# Altium schematic sheet RAK3172.SchDoc(RAK3172)
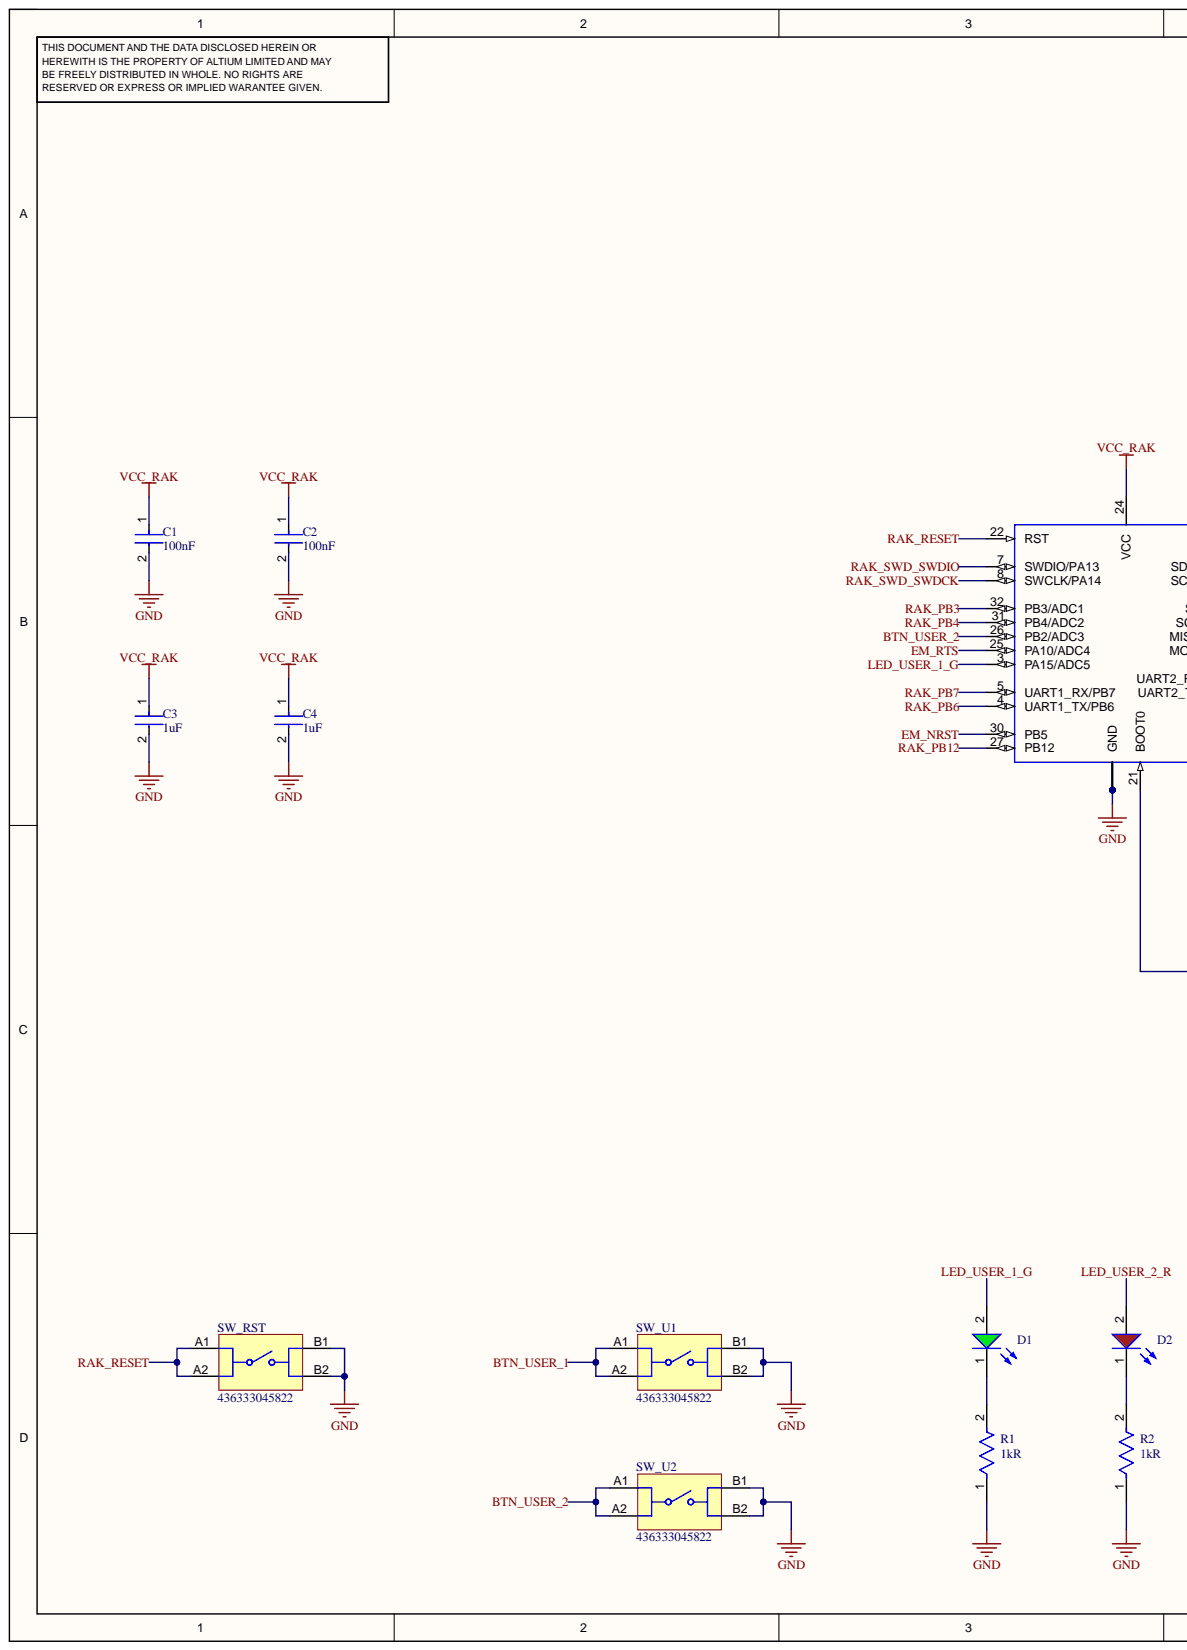
[diagram p0: RAK3172.SchDoc, left]
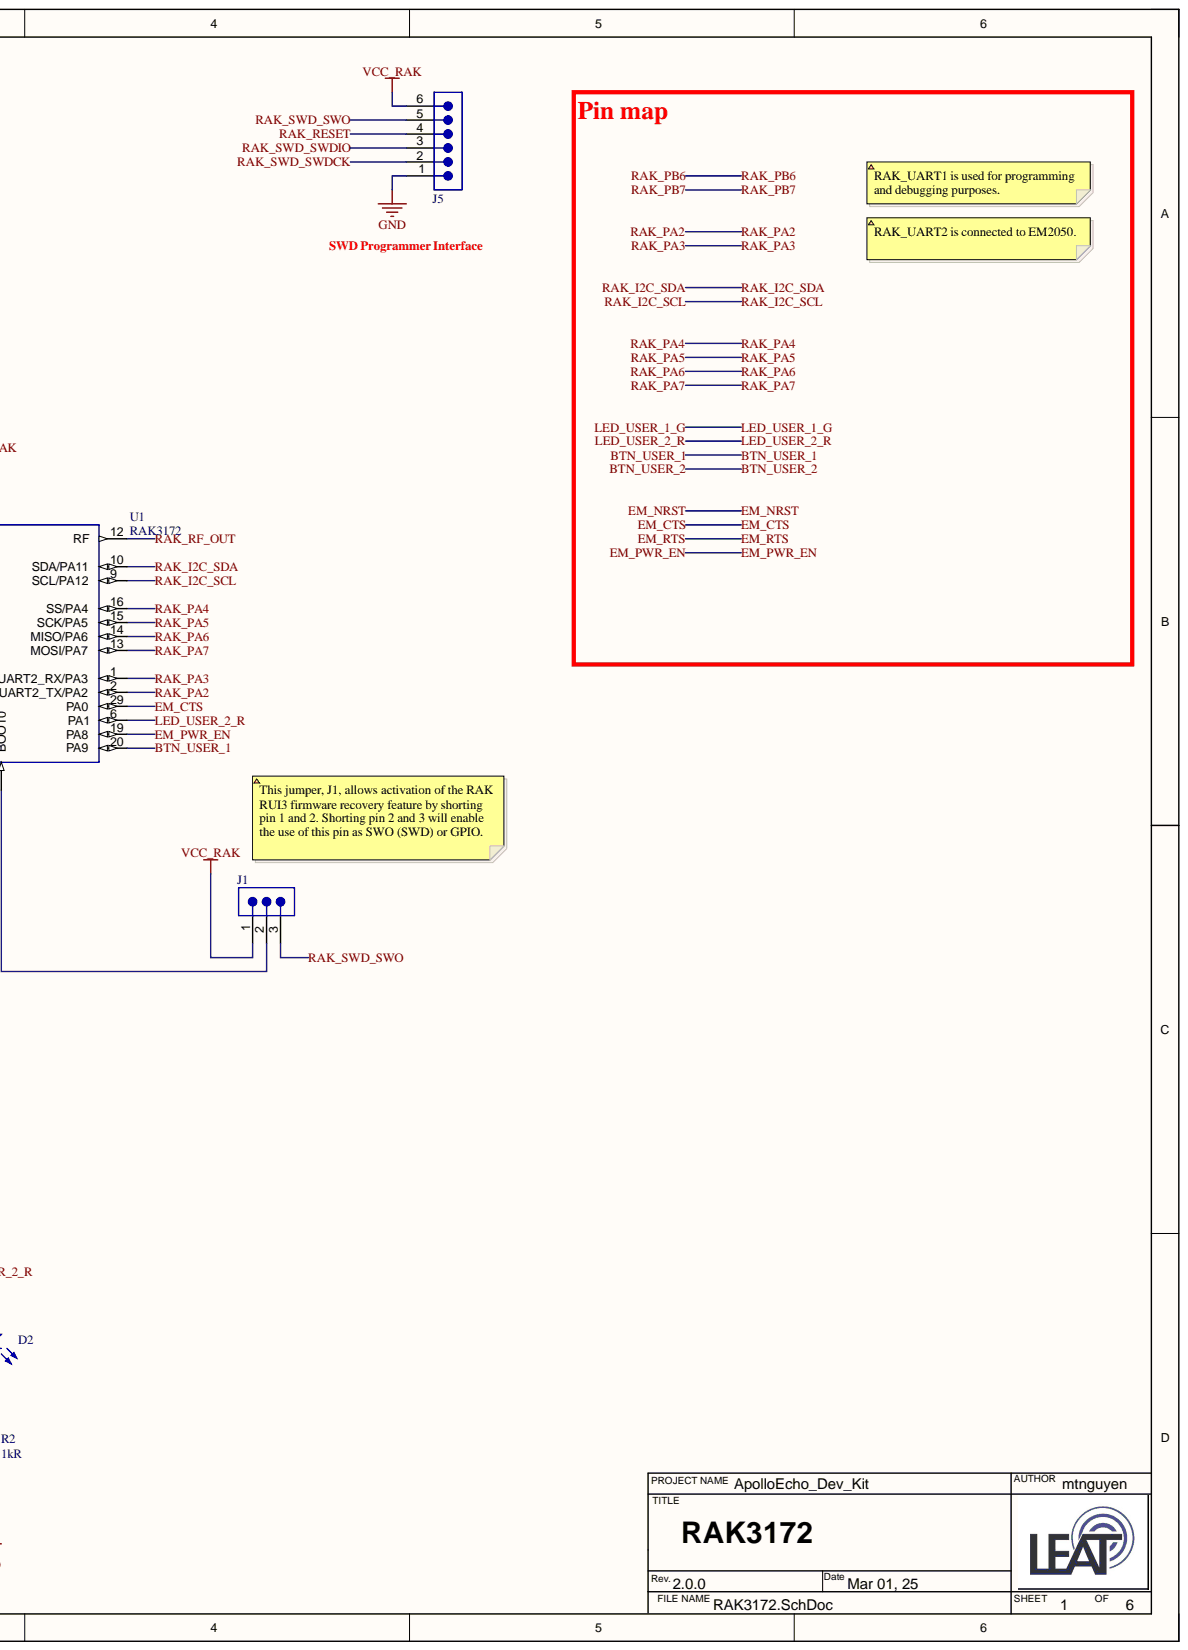
[diagram p1: RAK3172.SchDoc, right]

## Parts
C1  Capacitance: 100nF  [FP-0402-L_1_0_1-W_0_5_0_1-IPC_C]  (hidden comment: 0402ZD104KAT2A)
C2  Capacitance: 100nF  [FP-0402-L_1_0_1-W_0_5_0_1-IPC_C]  (hidden comment: 0402ZD104KAT2A)
C3  Capacitance: 1uF  [FP-0402-L_1_0_0_05-W_0_5-IPC_A]  (hidden comment: EMK105BJ105KV-F)
C4  Capacitance: 1uF  [FP-0402-L_1_0_0_05-W_0_5-IPC_A]  (hidden comment: EMK105BJ105KV-F)
D1  150060VS75000  [WL-SMCW_0603_150060xx75000]  (value not drawn)
D2  150060RS75000  [WL-SMCW_0603_150060xx75000]  (value not drawn)
J1  61300311121  [61300311121]  (value not drawn)
J5  61300611121  [61300611121]  (value not drawn)
R1  Resistance: 1kR  [FP-RMCF0402-IPC_A]  (hidden comment: RMCF0402FT1K00)
R2  Resistance: 1kR  [FP-RMCF0402-IPC_A]  (hidden comment: RMCF0402FT1K00)
SW_RST  436333045822  [436333045822]
SW_U1  436333045822  [436333045822]
SW_U2  436333045822  [436333045822]
U1  RAK3172  [RAK3172]

## Nets
GND: C1.2 C2.2 C3.2 C4.2 J5.1 R1.1 R2.1 SW_RST.B1 SW_RST.B2 SW_U1.B1 SW_U1.B2 SW_U2.B1 SW_U2.B2 U1.11(GND) U1.17 U1.18 U1.23 U1.28
VCC_RAK: C1.1 C2.1 C3.1 C4.1 J1.1 J5.6 U1.24(VCC)
BTN_USER_1: SW_U1.A1 SW_U1.A2 U1.20(PA9)
BTN_USER_2: SW_U2.A1 SW_U2.A2 U1.26(PB2/ADC3)
EM_CTS: U1.29(PA0)
EM_NRST: U1.30(PB5)
EM_PWR_EN: U1.19(PA8)
EM_RTS: U1.25(PA10/ADC4)
LED_USER_1_G: D1.2 U1.3(PA15/ADC5)
LED_USER_2_R: D2.2 U1.6(PA1)
RAK_I2C_SCL: U1.9(SCL/PA12)
RAK_I2C_SDA: U1.10(SDA/PA11)
RAK_PA2: U1.2(UART2_TX/PA2)
RAK_PA3: U1.1(UART2_RX/PA3)
RAK_PA4: U1.16(SS/PA4)
RAK_PA5: U1.15(SCK/PA5)
RAK_PA6: U1.14(MISO/PA6)
RAK_PA7: U1.13(MOSI/PA7)
RAK_PB3: U1.32(PB3/ADC1)
RAK_PB4: U1.31(PB4/ADC2)
RAK_PB6: U1.4(UART1_TX/PB6)
RAK_PB7: U1.5(UART1_RX/PB7)
RAK_PB12: U1.27(PB12)
RAK_RESET: J5.4 SW_RST.A1 SW_RST.A2 U1.22(RST)
RAK_RF_OUT: U1.12(RF)
RAK_SWD_SWDCK: J5.2 U1.8(SWCLK/PA14)
RAK_SWD_SWDIO: J5.3 U1.7(SWDIO/PA13)
RAK_SWD_SWO: J1.3 J5.5
NetD1_1: D1.1 R1.2
NetD2_1: D2.1 R2.2
NetJ1_2: J1.2 U1.21(BOOT0)

## Library data of the parts
C1 C2 = CMP-2008-02878-3: General Purpose Ceramic Capacitor, 0402, 100nF, 10%, X5R, 15%, 10V; Dissipation Factor: 5%; Insulation Resistance: 100GR; Case Code (Imperial): 0402; Case Code (Metric): 1005; Case/Package: 0402; Component Kind: Standard; Construction: Flat; Contact Plating: Tin; Depth: 0.5mm; Dielectric: X5R; Height: 0.56mm; Lead Free: Lead Free; Length: 1mm; Library Name: Altium Content Vault; Max Operating Temperature: 85°C; Min Operating Temperature: -55°C; Mount: Surface Mount; Package Quantity: 1; Packaging: Tape and Reel; Radiation Hardening: No; REACH SVHC: No SVHC; RoHS Compliant: Yes; Series: -; Termination: SMD/SMT; Thickness: 0.022inch; Tolerance: 10%; Voltage: 10V; Voltage Rating: 10V; Voltage Rating (DC): 10V; Width: 0.02inch
C3 C4 = CMP-14477-000104-2: None; Dielectric: X5R; Tolerance: 10%; Voltage Rating: 16V; Case Code (Imperial): 0402; Case Code (Metric): 1005; Case/Package: 0402; Component Kind: Standard; Construction: Flat; Depth: 0.5mm; Halogen Free: Halogen Free; Height: 0.5mm; Length: 1mm; Library Name: Altium Content Vault; Max Operating Temperature: 85°C; Min Operating Temperature: -55°C; Mount: Surface Mount; Number of Pins: 2; Package Quantity: 1; Packaging: Tape and Reel; Radiation Hardening: No; RoHS Compliant: Yes; Series: M; Simulation: EMK105BJ105_V-F; Termination: SMD/SMT; Thickness: 0.022inch; Voltage Rating (DC): 16V; Width: 0.02inch
D1 = CMP-1426-00006-7: WURTH ELEKTRONIK - 150060VS75000 - LED, Green, SMD, 0603, 30 mA, 2 V, 570 nm; Dominant Wavelength: 570nm; Forward Current: 100mA; Forward Voltage: 2V; Lens Style: Clear, Rectangular; Luminous Intensity: 40mcd; Max Junction Temperature (Tj): 95°C; Max Reverse Voltage (DC): 5V; Peak Wavelength: 572nm; Power Dissipation: 72mW; Test Current: 20mA; Viewing Angle: 140°; Case Code (Imperial): 0603; Case Code (Metric): 1608; Case/Package: 0603; Color: Green; Component Kind: Standard; Height: 700µm; Length: 1.6mm; Library Name: Altium Content Vault; Max Operating Temperature: 85°C; Min Operating Temperature: -40°C; Mount: Surface Mount; Number of Pins: 2; Packaging: Tape and Reel; REACH SVHC: Unknown; Reverse Voltage: 5V; RoHS: Compliant; Wavelength: 570nm; Width: 800µm
D2 = CMP-1426-00001-4: LED Low-Power Uni-Color Red 630nm 2V 2-Pin Chip 0603(1608Metric) T/R; Dominant Wavelength: 625nm; Forward Current: 100mA; Lens Style: Clear, Rectangular; Luminous Intensity: 250mcd; Max Junction Temperature (Tj): 95°C; Max Reverse Voltage (DC): 5V; Power Dissipation: 72mW; Test Current: 20mA; Viewing Angle: 140°; Case Code (Imperial): 0603; Case Code (Metric): 1608; Case/Package: 0603; Color: Red; Component Kind: Standard; Forward Voltage: 2V; Height: 700µm; Length: 1.6mm; Library Name: Altium Content Vault; Max Operating Temperature: 85°C; Min Operating Temperature: -40°C; Mount: Surface Mount; Packaging: Tape and Reel; Peak Wavelength: 630nm; REACH SVHC: Unknown; Reverse Voltage: 5V; RoHS: Compliant; Wavelength: 625nm; Width: 800µm
J1 = CMP-1502-01062-3: NexarPartId: 32855521; Connector Type: Male Pin; Contact Resistance: 20mΩ; Current Rating: 3A; Gender: Male; Number of Contacts: 3; Operating Supply Voltage: 250V; Pitch: 2.54mm; Color: Black; Component Kind: Standard; Contact Material: Copper; Contact Mating Length: 5.9944mm; Contact Plating: Gold; Height: 8.94mm; Lead Free: Lead Free; Lead Pitch: 2.54mm; Library Name: Altium Content Vault; Max Operating Temperature: 105°C; Min Operating Temperature: -40°C; Mount: Through Hole; Number of Pins: 3; Number of Positions: 3; Number of Rows: 1; Orientation: Vertical; Packaging: Bulk; REACH SVHC: Unknown; RoHS: Compliant; Termination: Solder; Voltage Rating: 250V
J5 = CMP-1502-01070-3: NexarPartId: 20084590; Connector Type: Male Pin; Contact Resistance: 20mΩ; Current Rating: 3A; Gender: Male; Number of Contacts: 6; Operating Supply Voltage: 250V; Pitch: 2.54mm; Color: Black; Component Kind: Standard; Contact Gender: Male; Contact Material: Copper; Contact Mating Length: 5.9944mm; Contact Plating: Gold; Height: 8.89mm; Housing Material: PBT; Lead Free: Lead Free; Lead Pitch: 2.54mm; Library Name: Altium Content Vault; Max Operating Temperature: 105°C; Min Operating Temperature: -40°C; Mount: Through Hole; Number of Pins: 6; Number of Positions: 6; Number of Rows: 1; Orientation: Vertical; Packaging: Bulk; REACH SVHC: Unknown; RoHS: Compliant; Termination: Through Hole; Voltage Rating: 250V
R1 R2 = CMP-26527-000026-1: 1kΩ ±1% 0.063W 0402 Thick Film Chip Resistor AEC-Q200 compliant; Max Power Dissipation: 63mW; Temperature Coefficient: 100ppm/°C; Case Code (Imperial): 0402; Case Code (Metric): 1005; Case/Package: 0402; Component Kind: Standard; Construction: Rectangular; Contact Plating: Tin; Depth: 0.5mm; Features: Automotive AEC-Q200; Height: 0.016inch; Lead Free: Lead Free; Length: 1mm; Library Name: Altium Content Vault; Max Operating Temperature: 155°C; Military Standard: Not; Min Operating Temperature: -55°C; Mount: Surface Mount; Number of Terminations: 2; Packaging: Tape and Reel; Power Rating: 63mW; Radiation Hardening: No; Resistor Type: Thick Film; RoHS Compliant: Yes; Series: RMCF; Size Code: 0402; Tolerance: 1%; Width: 0.02inch
SW_RST SW_U1 SW_U2 = CMP-1464-00001-2: NexarPartId: 22866045; Contact Current Rating: 50mA; Contact Resistance: 100mΩ; Operating Force: 220N; Voltage Rating: 12V; Component Kind: Standard; Contact Plating: Silver; Current Rating: 50mA; Height: 1.65mm; Insulation Resistance: 100MΩ; Library Name: Altium Content Vault; Max Operating Temperature: 85°C; Min Operating Temperature: -40°C; Packaging: Tape and Reel; RoHS: Compliant; Width: 4.7mm
U1 = RAK3172: RAK3172 is a low-power long-range transceiver module based on the STM32WLE5CC chip. It provides an easy-to-use, small-size, low-power solution for long range wireless data applications; MF: Shenzhen RAKwireless Technology Co.,Ltd.; Availability: Not in stock; Check_prices: https://www.snapeda.com/parts/RAK3172/Shenzhen+RAKwireless+Technology+Co.%252CLtd./view-part/?ref=eda; Component Kind: Standard; Library Name: RAK3172.IntLib; MP: RAK3172; Package: Package; Price: None; SnapEDA_Link: https://www.snapeda.com/parts/RAK3172/Shenzhen+RAKwireless+Technology+Co.%252CLtd./view-part/?ref=snap
